# Altium schematic sheet encoder.SchDoc
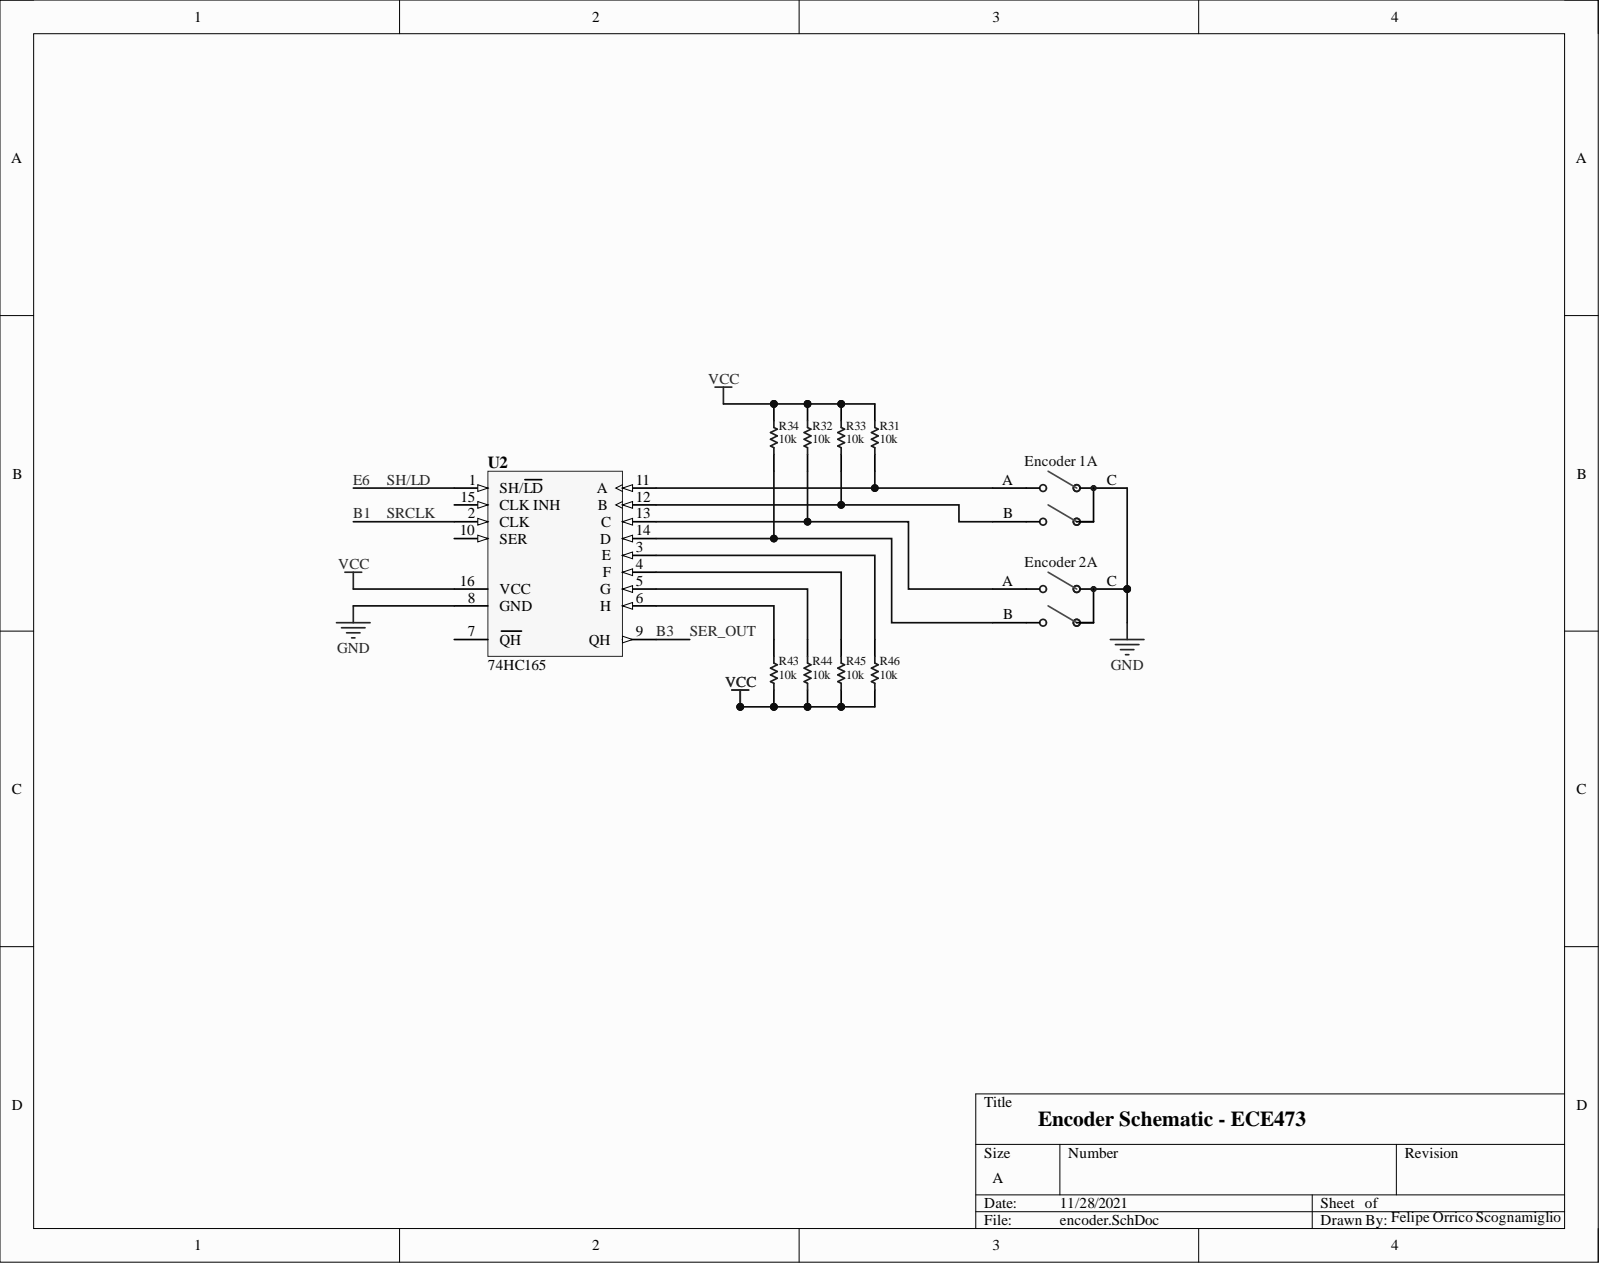
[diagram p0: encoder.SchDoc]

## Parts
Encoder_1  (no value)  [PCB-71mmi5uud4gff1s0luof-1]  (part A)
Encoder_2  (no value)  [PCB-71mmi5uud4gff1s0luof-1]  (part A)
R31  10k  [PCB-q466dezs08uszq8774n0-1]
R32  10k  [PCB-q466dezs08uszq8774n0-1]
R33  10k  [PCB-q466dezs08uszq8774n0-1]
R34  10k  [PCB-q466dezs08uszq8774n0-1]
R43  10k  [PCB-q466dezs08uszq8774n0-1]
R44  10k  [PCB-q466dezs08uszq8774n0-1]
R45  10k  [PCB-q466dezs08uszq8774n0-1]
R46  10k  [PCB-q466dezs08uszq8774n0-1]
U2  74HC165  [PCB-973609-1]

## Nets
GND: Encoder_1.C Encoder_2.C U2.8(GND)
VCC: R31.2 R32.2 R33.2 R34.2 R43.1 R44.1 R45.1 R46.1 U2.16(VCC)
B1: U2.2(CLK)
B3: U2.9(QH)
E6: U2.1(SH/LD)
NetEncoder 1_A: Encoder_1.A R31.1 U2.11(A)
NetEncoder 1_B: Encoder_1.B R33.1 U2.12(B)
NetEncoder 2_A: Encoder_2.A R32.1 U2.13(C)
NetEncoder 2_B: Encoder_2.B R34.1 U2.14(D)
NetR43_2: R43.2 U2.6(H)
NetR44_2: R44.2 U2.5(G)
NetR45_2: R45.2 U2.4(F)
NetR46_2: R46.2 U2.3(E)

Not connected: U2 7(QH) 10(SER) 15(CLK_INH)

## Library data of the parts
Encoder_1 Encoder_2 = CMP-1cebd4858adbc32c-1: 12mm Rotary Encoder with Switch Horizontal 25mm Knurled Shaft Green/Red LED 7-Pin; Manufacturer Part Number: DPL12SHN24A25K3; ELV Compliant: Compliant; Component Kind: Standard; Library Name: My Personal Space; Lifecycle Status: Active; Octopart URL: https://octopart.com/dpl12shn24a25k3-te+connectivity-23376726; OctopartID: 1cebd4858adbc32c; RoHS: Compliant
R31-R34 R43-R46 = CMP-e82f23a0358237e8-1: RC Series 0201 0.05 W 1 kOhms 5% ±200 ppm/°C SMT Thick Film Chip Resistor; Manufacturer Part Number: RC0201JR-071KL; Lead-Free Status: Lead Free; Power Rating: 50.0 mW; Resistance: 1.00 kΩ; Voltage Rating (DC): 25.0 V; Case/Package: 0201; Component Kind: Standard; Library Name: My Personal Space; Mounting Style: Surface Mount; Number of Pins: 2; Octopart URL: http://octopart.com/rc0201jr-071kl-yageo-42334411; OctopartID: e82f23a0358237e8; Packaging: Tape & Reel; REACH SVHC Compliance: No SVHC; Resistance Tolerance: ±5%; RoHS: Compliant; Size-Length: 600 µm
U2 = CMP-973609-14: Semiconductor Counter Shift Registers 8bit Serial/Parallel In Serial Ou; Component Kind: Standard; Library Name: My Personal Space
